# Altium schematic sheet SCM20260D-IO.SchDoc
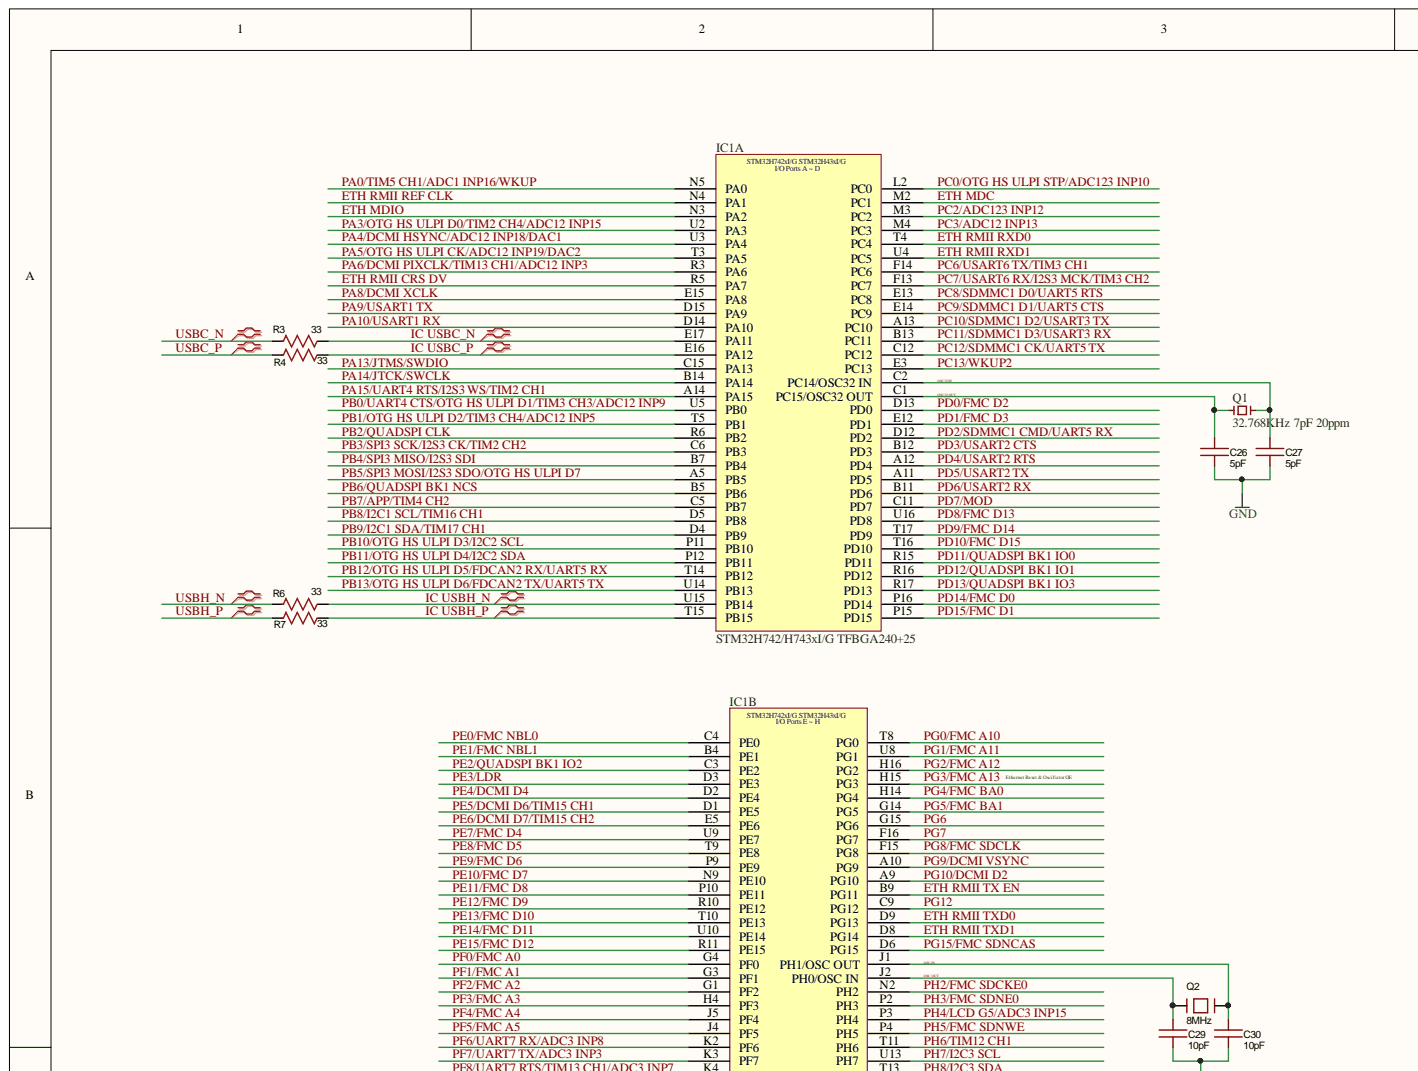
[diagram p0: SCM20260D-IO.SchDoc, top left]
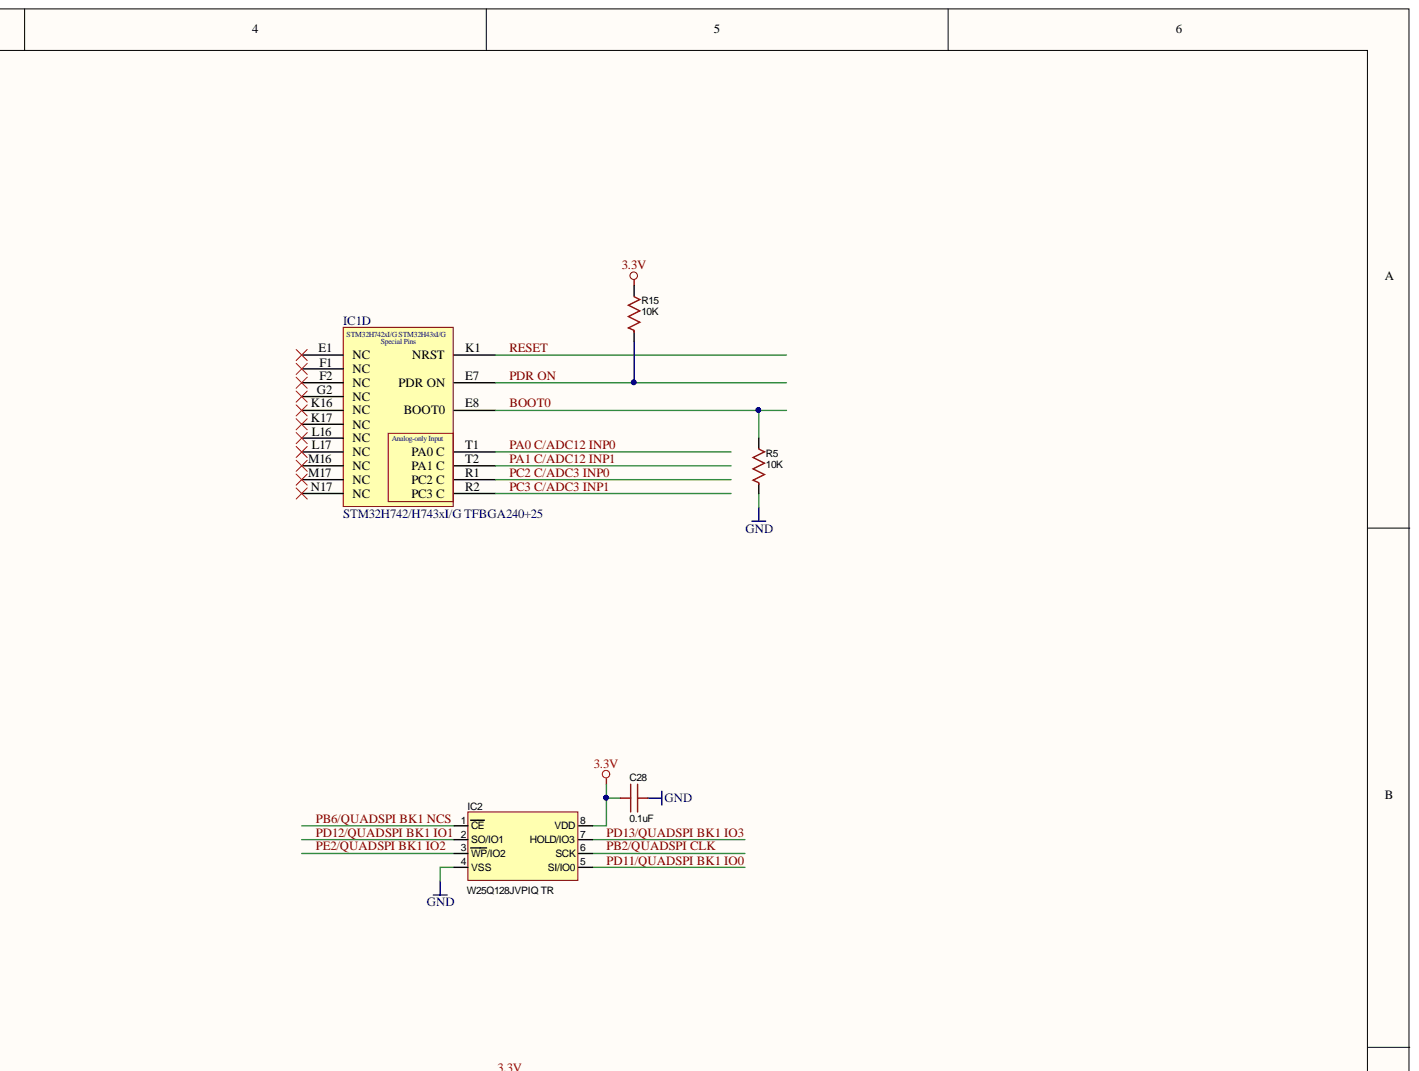
[diagram p1: SCM20260D-IO.SchDoc, top right]
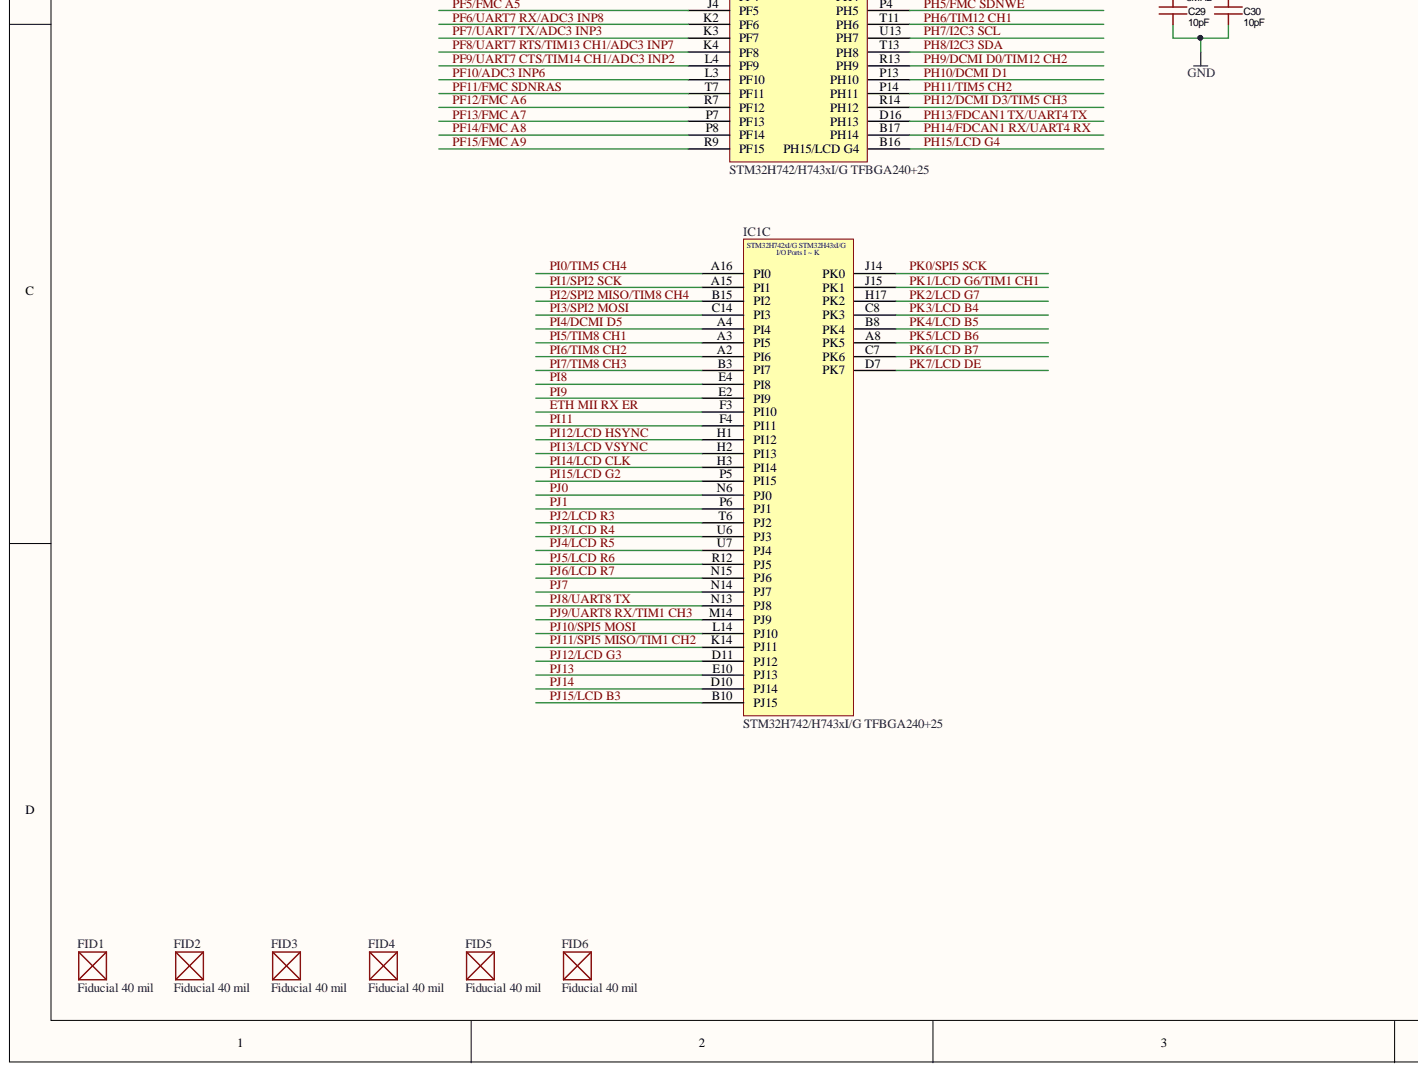
[diagram p2: SCM20260D-IO.SchDoc, bottom left]
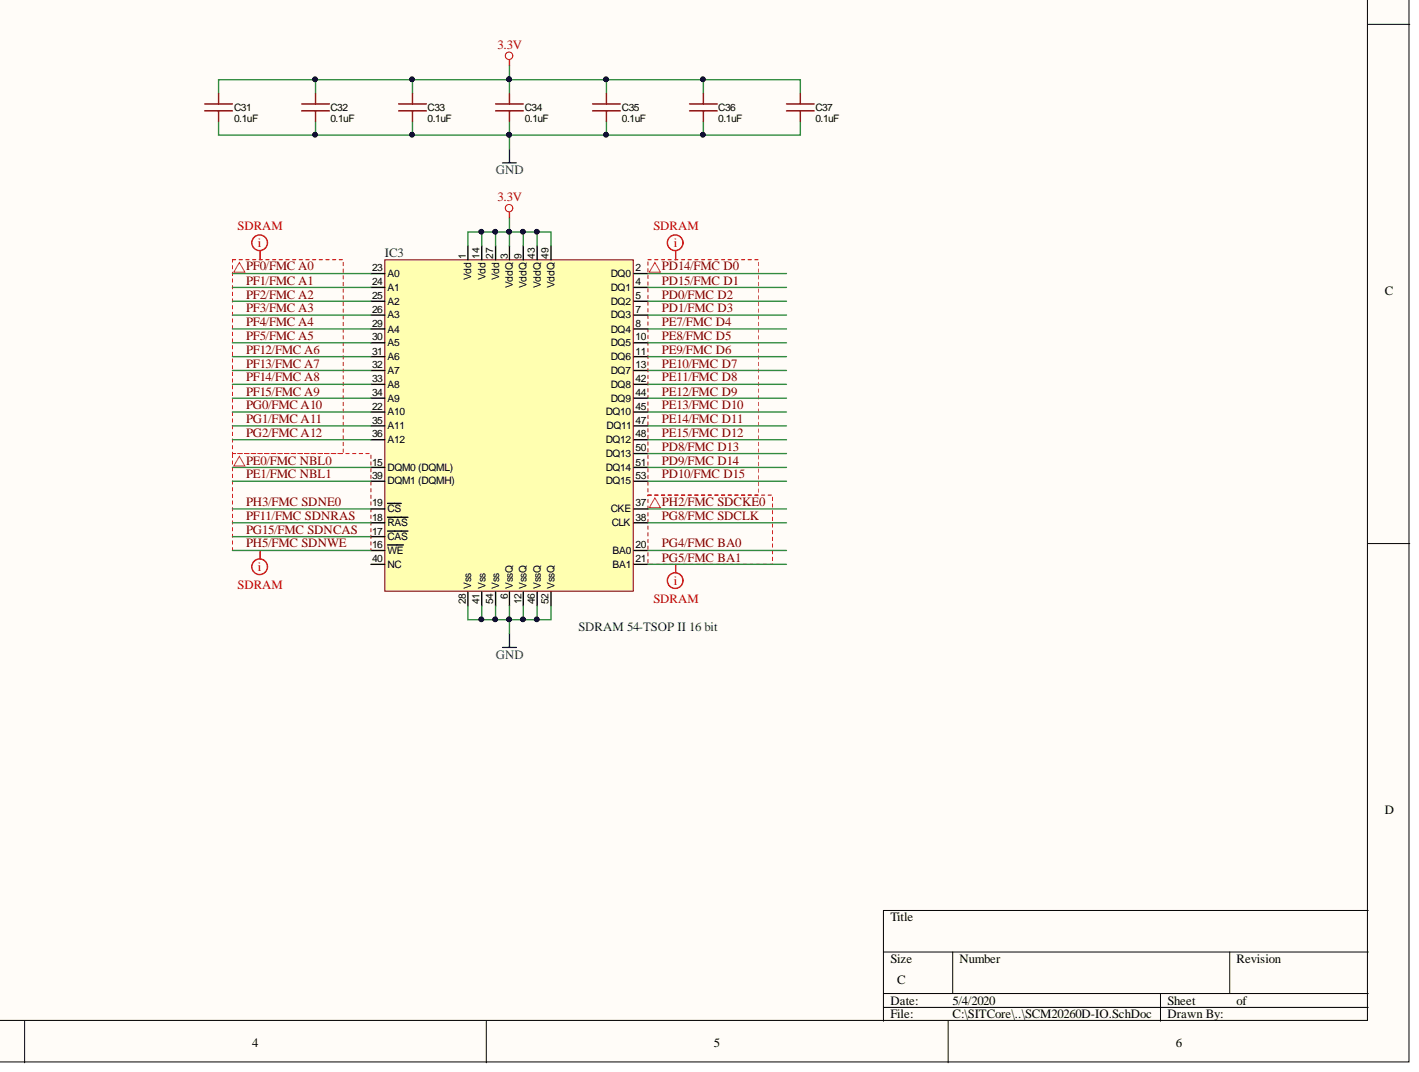
[diagram p3: SCM20260D-IO.SchDoc, bottom right]

## Parts
C26  value 5pF  Capacitance: 5pF  [C0402]  (hidden comment: 0402 Cap 5pF)
C27  value 5pF  Capacitance: 5pF  [C0402]  (hidden comment: 0402 Cap 5pF)
C28  value 0.1uF  Capacitance: 0.1uF  [C0402]  (hidden comment: 0402 Cap 0.1uF)
C29  value 10pF  Capacitance: 10pF  [C0402]  (hidden comment: 0402 Cap 10pF)
C30  value 10pF  Capacitance: 10pF  [C0402]  (hidden comment: 0402 Cap 10pF)
C31  value 0.1uF  Capacitance: 0.1uF  [C0402]  (hidden comment: 0402 Cap 0.1uF)
C32  value 0.1uF  Capacitance: 0.1uF  [C0402]  (hidden comment: 0402 Cap 0.1uF)
C33  value 0.1uF  Capacitance: 0.1uF  [C0402]  (hidden comment: 0402 Cap 0.1uF)
C34  value 0.1uF  Capacitance: 0.1uF  [C0402]  (hidden comment: 0402 Cap 0.1uF)
C35  value 0.1uF  Capacitance: 0.1uF  [C0402]  (hidden comment: 0402 Cap 0.1uF)
C36  value 0.1uF  Capacitance: 0.1uF  [C0402]  (hidden comment: 0402 Cap 0.1uF)
C37  value 0.1uF  Capacitance: 0.1uF  [C0402]  (hidden comment: 0402 Cap 0.1uF)
FID1  Fiducial 40 mil  [Fiducial 40 Mil]
FID2  Fiducial 40 mil  [Fiducial 40 Mil]
FID3  Fiducial 40 mil  [Fiducial 40 Mil]
FID4  Fiducial 40 mil  [Fiducial 40 Mil]
FID5  Fiducial 40 mil  [Fiducial 40 Mil]
FID6  Fiducial 40 mil  [Fiducial 40 Mil]
IC1  STM32H742/H743xI/G TFBGA240+25  [TFBGA240+25]  (parts A,B,C,D)
IC2  value W25Q128JVPIQ TR  Mfr.Part #: W25Q128JVPIQ TR  [WSON 8 6x5mm]  (hidden comment: FLASH - NOR Memory IC 128Mb)
IC3  SDRAM 54-TSOP II 16 bit  [TSOP-54]
Q1  32.768KHz 7pF 20ppm  Output Frequency: 32.768KHz  [Crystal 3.2mm x 1.5mm]
Q2  value 8MHz  [CRYSTAL_3.2X2.5]  (hidden comment: CRYSTAL3.2X2.5)
R3  value 33  [R0402]  (hidden comment: 0402 Res 33)
R4  value 33  [R0402]  (hidden comment: 0402 Res 33)
R5  value 10K  Resistance: 10K  [R0402]  (hidden comment: 0402 Res 10K)
R6  value 33  [R0402]  (hidden comment: 0402 Res 33)
R7  value 33  [R0402]  (hidden comment: 0402 Res 33)
R15  value 10K  Resistance: 10K  [R0402]  (hidden comment: 0402 Res 10K)

## Nets
3.3V: C28.1 C31.2 C32.2 C33.2 C34.2 C35.2 C36.2 C37.2 IC2.8(VDD) IC3.1(Vdd) IC3.3(VddQ) IC3.9(VddQ) IC3.14(Vdd) IC3.27(Vdd) IC3.43(VddQ) IC3.49(VddQ) R15.2
GND: C26.1 C27.1 C28.2 C29.1 C30.1 C31.1 C32.1 C33.1 C34.1 C35.1 C36.1 C37.1 IC2.4(VSS) IC3.6(VssQ) IC3.12(VssQ) IC3.28(Vss) IC3.41(Vss) IC3.46(VssQ) IC3.52(VssQ) IC3.54(Vss) R5.1
BOOT0: IC1.E8(BOOT0) R5.2
ETH MDC: IC1.M2(PC1)
ETH MDIO: IC1.N3(PA2)
ETH MII RX ER: IC1.F3(PI10)
ETH RMII CRS DV: IC1.R5(PA7)
ETH RMII REF CLK: IC1.N4(PA1)
ETH RMII RXD0: IC1.T4(PC4)
ETH RMII RXD1: IC1.U4(PC5)
ETH RMII TX EN: IC1.B9(PG11)
ETH RMII TXD0: IC1.D9(PG13)
ETH RMII TXD1: IC1.D8(PG14)
IC USBC_N: IC1.E17(PA11) R3.2
IC USBC_P: IC1.E16(PA12) R4.2
IC USBH_N: IC1.U15(PB14) R6.2
IC USBH_P: IC1.T15(PB15) R7.2
OSC32 IN: C27.2 IC1.C2(PC14/OSC32_IN) Q1.2
OSC32 OUT: C26.2 IC1.C1(PC15/OSC32_OUT) Q1.1
OSC IN: C30.2 IC1.J1(PH1/OSC_OUT) Q2.3
OSC OUT: C29.2 IC1.J2(PH0/OSC_IN) Q2.1
PA0 C/ADC12 INP0: IC1.T1(PA0_C)
PA0/TIM5 CH1/ADC1 INP16/WKUP: IC1.N5(PA0)
PA1 C/ADC12 INP1: IC1.T2(PA1_C)
PA3/OTG HS ULPI D0/TIM2 CH4/ADC12 INP15: IC1.U2(PA3)
PA4/DCMI HSYNC/ADC12 INP18/DAC1: IC1.U3(PA4)
PA5/OTG HS ULPI CK/ADC12 INP19/DAC2: IC1.T3(PA5)
PA6/DCMI PIXCLK/TIM13 CH1/ADC12 INP3: IC1.R3(PA6)
PA8/DCMI XCLK: IC1.E15(PA8)
PA9/USART1 TX: IC1.D15(PA9)
PA10/USART1 RX: IC1.D14(PA10)
PA13/JTMS/SWDIO: IC1.C15(PA13)
PA14/JTCK/SWCLK: IC1.B14(PA14)
PA15/UART4 RTS/I2S3 WS/TIM2 CH1: IC1.A14(PA15)
PB0/UART4 CTS/OTG HS ULPI D1/TIM3 CH3/ADC12 INP9: IC1.U5(PB0)
PB1/OTG HS ULPI D2/TIM3 CH4/ADC12 INP5: IC1.T5(PB1)
PB2/QUADSPI CLK: IC1.R6(PB2) IC2.6(SCK)
PB3/SPI3 SCK/I2S3 CK/TIM2 CH2: IC1.C6(PB3)
PB4/SPI3 MISO/I2S3 SDI: IC1.B7(PB4)
PB5/SPI3 MOSI/I2S3 SDO/OTG HS ULPI D7: IC1.A5(PB5)
PB6/QUADSPI BK1 NCS: IC1.B5(PB6) IC2.1(CE)
PB7/APP/TIM4 CH2: IC1.C5(PB7)
PB8/I2C1 SCL/TIM16 CH1: IC1.D5(PB8)
PB9/I2C1 SDA/TIM17 CH1: IC1.D4(PB9)
PB10/OTG HS ULPI D3/I2C2 SCL: IC1.P11(PB10)
PB11/OTG HS ULPI D4/I2C2 SDA: IC1.P12(PB11)
PB12/OTG HS ULPI D5/FDCAN2 RX/UART5 RX: IC1.T14(PB12)
PB13/OTG HS ULPI D6/FDCAN2 TX/UART5 TX: IC1.U14(PB13)
PC0/OTG HS ULPI STP/ADC123 INP10: IC1.L2(PC0)
PC2 C/ADC3 INP0: IC1.R1(PC2_C)
PC2/ADC123 INP12: IC1.M3(PC2)
PC3 C/ADC3 INP1: IC1.R2(PC3_C)
PC3/ADC12 INP13: IC1.M4(PC3)
PC6/USART6 TX/TIM3 CH1: IC1.F14(PC6)
PC7/USART6 RX/I2S3 MCK/TIM3 CH2: IC1.F13(PC7)
PC8/SDMMC1 D0/UART5 RTS: IC1.E13(PC8)
PC9/SDMMC1 D1/UART5 CTS: IC1.E14(PC9)
PC10/SDMMC1 D2/USART3 TX: IC1.A13(PC10)
PC11/SDMMC1 D3/USART3 RX: IC1.B13(PC11)
PC12/SDMMC1 CK/UART5 TX: IC1.C12(PC12)
PC13/WKUP2: IC1.E3(PC13)
PD0/FMC D2: IC1.D13(PD0) IC3.5(DQ2)
PD1/FMC D3: IC1.E12(PD1) IC3.7(DQ3)
PD2/SDMMC1 CMD/UART5 RX: IC1.D12(PD2)
PD3/USART2 CTS: IC1.B12(PD3)
PD4/USART2 RTS: IC1.A12(PD4)
PD5/USART2 TX: IC1.A11(PD5)
PD6/USART2 RX: IC1.B11(PD6)
PD7/MOD: IC1.C11(PD7)
PD8/FMC D13: IC1.U16(PD8) IC3.50(DQ13)
PD9/FMC D14: IC1.T17(PD9) IC3.51(DQ14)
PD10/FMC D15: IC1.T16(PD10) IC3.53(DQ15)
PD11/QUADSPI BK1 IO0: IC1.R15(PD11) IC2.5(SI/IO0)
PD12/QUADSPI BK1 IO1: IC1.R16(PD12) IC2.2(SO/IO1)
PD13/QUADSPI BK1 IO3: IC1.R17(PD13) IC2.7(HOLD/IO3)
PD14/FMC D0: IC1.P16(PD14) IC3.2(DQ0)
PD15/FMC D1: IC1.P15(PD15) IC3.4(DQ1)
PDR ON: IC1.E7(PDR_ON) R15.1
PE0/FMC NBL0: IC1.C4(PE0) IC3.15(DQM0_(DQML))
PE1/FMC NBL1: IC1.B4(PE1) IC3.39(DQM1_(DQMH))
PE2/QUADSPI BK1 IO2: IC1.C3(PE2) IC2.3(WP/IO2)
PE3/LDR: IC1.D3(PE3)
PE4/DCMI D4: IC1.D2(PE4)
PE5/DCMI D6/TIM15 CH1: IC1.D1(PE5)
PE6/DCMI D7/TIM15 CH2: IC1.E5(PE6)
PE7/FMC D4: IC1.U9(PE7) IC3.8(DQ4)
PE8/FMC D5: IC1.T9(PE8) IC3.10(DQ5)
PE9/FMC D6: IC1.P9(PE9) IC3.11(DQ6)
PE10/FMC D7: IC1.N9(PE10) IC3.13(DQ7)
PE11/FMC D8: IC1.P10(PE11) IC3.42(DQ8)
PE12/FMC D9: IC1.R10(PE12) IC3.44(DQ9)
PE13/FMC D10: IC1.T10(PE13) IC3.45(DQ10)
PE14/FMC D11: IC1.U10(PE14) IC3.47(DQ11)
PE15/FMC D12: IC1.R11(PE15) IC3.48(DQ12)
PF0/FMC A0: IC1.G4(PF0) IC3.23(A0)
PF1/FMC A1: IC1.G3(PF1) IC3.24(A1)
PF2/FMC A2: IC1.G1(PF2) IC3.25(A2)
PF3/FMC A3: IC1.H4(PF3) IC3.26(A3)
PF4/FMC A4: IC1.J5(PF4) IC3.29(A4)
PF5/FMC A5: IC1.J4(PF5) IC3.30(A5)
PF6/UART7 RX/ADC3 INP8: IC1.K2(PF6)
PF7/UART7 TX/ADC3 INP3: IC1.K3(PF7)
PF8/UART7 RTS/TIM13 CH1/ADC3 INP7: IC1.K4(PF8)
PF9/UART7 CTS/TIM14 CH1/ADC3 INP2: IC1.L4(PF9)
PF10/ADC3 INP6: IC1.L3(PF10)
PF11/FMC SDNRAS: IC1.T7(PF11) IC3.18(RAS)
PF12/FMC A6: IC1.R7(PF12) IC3.31(A6)
PF13/FMC A7: IC1.P7(PF13) IC3.32(A7)
PF14/FMC A8: IC1.P8(PF14) IC3.33(A8)
PF15/FMC A9: IC1.R9(PF15) IC3.34(A9)
PG0/FMC A10: IC1.T8(PG0) IC3.22(A10)
PG1/FMC A11: IC1.U8(PG1) IC3.35(A11)
PG2/FMC A12: IC1.H16(PG2) IC3.36(A12)
PG3/FMC A13: IC1.H15(PG3)
PG4/FMC BA0: IC1.H14(PG4) IC3.20(BA0)
PG5/FMC BA1: IC1.G14(PG5) IC3.21(BA1)
PG6: IC1.G15(PG6)
PG7: IC1.F16(PG7)
PG8/FMC SDCLK: IC1.F15(PG8) IC3.38(CLK)
PG9/DCMI VSYNC: IC1.A10(PG9)
PG10/DCMI D2: IC1.A9(PG10)
PG12: IC1.C9(PG12)
PG15/FMC SDNCAS: IC1.D6(PG15) IC3.17(CAS)
PH2/FMC SDCKE0: IC1.N2(PH2) IC3.37(CKE)
PH3/FMC SDNE0: IC1.P2(PH3) IC3.19(CS)
PH4/LCD G5/ADC3 INP15: IC1.P3(PH4)
PH5/FMC SDNWE: IC1.P4(PH5) IC3.16(WE)
PH6/TIM12 CH1: IC1.T11(PH6)
PH7/I2C3 SCL: IC1.U13(PH7)
PH8/I2C3 SDA: IC1.T13(PH8)
PH9/DCMI D0/TIM12 CH2: IC1.R13(PH9)
PH10/DCMI D1: IC1.P13(PH10)
PH11/TIM5 CH2: IC1.P14(PH11)
PH12/DCMI D3/TIM5 CH3: IC1.R14(PH12)
PH13/FDCAN1 TX/UART4 TX: IC1.D16(PH13)
PH14/FDCAN1 RX/UART4 RX: IC1.B17(PH14)
PH15/LCD G4: IC1.B16(PH15/LCD_G4)
PI0/TIM5 CH4: IC1.A16(PI0)
PI1/SPI2 SCK: IC1.A15(PI1)
PI2/SPI2 MISO/TIM8 CH4: IC1.B15(PI2)
PI3/SPI2 MOSI: IC1.C14(PI3)
PI4/DCMI D5: IC1.A4(PI4)
PI5/TIM8 CH1: IC1.A3(PI5)
PI6/TIM8 CH2: IC1.A2(PI6)
PI7/TIM8 CH3: IC1.B3(PI7)
PI8: IC1.E4(PI8)
PI9: IC1.E2(PI9)
PI11: IC1.F4(PI11)
PI12/LCD HSYNC: IC1.H1(PI12)
PI13/LCD VSYNC: IC1.H2(PI13)
PI14/LCD CLK: IC1.H3(PI14)
PI15/LCD G2: IC1.P5(PI15)
PJ0: IC1.N6(PJ0)
PJ1: IC1.P6(PJ1)
PJ2/LCD R3: IC1.T6(PJ2)
PJ3/LCD R4: IC1.U6(PJ3)
PJ4/LCD R5: IC1.U7(PJ4)
PJ5/LCD R6: IC1.R12(PJ5)
PJ6/LCD R7: IC1.N15(PJ6)
PJ7: IC1.N14(PJ7)
PJ8/UART8 TX: IC1.N13(PJ8)
PJ9/UART8 RX/TIM1 CH3: IC1.M14(PJ9)
PJ10/SPI5 MOSI: IC1.L14(PJ10)
PJ11/SPI5 MISO/TIM1 CH2: IC1.K14(PJ11)
PJ12/LCD G3: IC1.D11(PJ12)
PJ13: IC1.E10(PJ13)
PJ14: IC1.D10(PJ14)
PJ15/LCD B3: IC1.B10(PJ15)
PK0/SPI5 SCK: IC1.J14(PK0)
PK1/LCD G6/TIM1 CH1: IC1.J15(PK1)
PK2/LCD G7: IC1.H17(PK2)
PK3/LCD B4: IC1.C8(PK3)
PK4/LCD B5: IC1.B8(PK4)
PK5/LCD B6: IC1.A8(PK5)
PK6/LCD B7: IC1.C7(PK6)
PK7/LCD DE: IC1.D7(PK7)
RESET: IC1.K1(NRST)
USBC_N: R3.1
USBC_P: R4.1
USBH_N: R6.1
USBH_P: R7.1
N00230:
N00231:

Not connected: IC1 E1(NC) F1(NC) F2(NC) G2(NC) K16(NC) K17(NC) L16(NC) L17(NC) M16(NC) M17(NC) N17(NC); IC3 40(NC)

## Library data of the parts
C26 C27 = 0402 Cap 5pF: 5pF ±0.1pF 50V C0G 0402 Multilayer Ceramic Capacitors MLCC - SMD/SMT RoHS; Manufacturer: Samsung Electro-Mechanics; Mfr.Part #: CL05C050BB5NNNC; Category: Ceramic; Component Kind: Standard; LCSC Part #: C318616; Package: 0402; Tolerance: ±0.1pF; Voltage: 50V; Library Name: GHI-Passives.SchLib
C28 C31-C37 = 0402 Cap 0.1uF: 0.1uF ±10% 16V X7R 0402 Multilayer Ceramic Capacitors MLCC - SMD/SMT RoHS; Mfr.Part #: CC0402KRX7R7BB104; Category: Ceramic; Component Kind: Standard; LCSC Part #: C60474; Package: 0402; Tolerance: ±10%; Voltage: 16V; Library Name: GHI-Passives.SchLib
C29 C30 = 0402 Cap 10pF: 10pF ±0.5pF 50V C0G 0402 Multilayer Ceramic Capacitors MLCC - SMD/SMT RoHS; Manufacturer: Samsung Electro-Mechanics; Mfr.Part #: CL05C100DB5NNNC; Category: Ceramic; Component Kind: Standard; LCSC Part #: C307440; Package: 0402; Tolerance: ±0.5pF; Voltage: 50V; Library Name: GHI-Passives.SchLib
IC1 = STM32H742/H743xI/G TFBGA240+25: STM32H742/H743xI/G TFBGA240+25 (14 x 14mm); Component Kind: Standard; Library Name: GHI Processors.SchLib
IC2 = W25Q128JVPIQ: Manufacturer: Winbond Elec; Component Kind: Standard; Description: FLASH - NOR Memory IC 128Mb; Digikey Part No: W25Q128JVPIQCT-ND; LCSC Part #: C190862; Package: 8-WDFN Exposed Pad; Library Name: GHI - Integrated Circuits.SchLib
Q1 = 32.768KHz 7pF 20ppm 2 Pad 3.2mm x 1.5mm: Crystal 2 Pad 3.2mm x 1.5mm 32.768KHz; GHI Part Number: EPC-Q32KHZ; Manufacturer Part Number: 6K30032768K0702070401; Aging @25 C 1st Year (Max): +- 3ppm/year; Driver Level (Typical): 1uW Max; Equivalent Series Resistance: 70k Ohm Max; Frequency Tolerance: +- 20ppm; Load Capacitance: 10 pF; Mode: AT/Fundamental; Shunt Capacitance: 1.3pF Typ; Component Kind: Standard; Frequency Stability: N/A; Library Name: GHI Oscillators.SchLib; Operating Temperature Range: -40 C to +85 C; Shock Resistance: N/A; Storage Temperature: -55C to +125 C
Q2 = CRYSTAL3.2X2.5: 4 pad 3.2 mm x 2.5 mm Crystal; GateName_1: G$1; SymbolName_1: CRYSTAL.2PAD; Component Kind: Standard; DeviceSetName: CRYSTAL3.2X2.5; LibraryName: GHI2; Library Name: GHI.SchLib
R3 R4 R6 R7 = 0402 Res 33: 33 ±1% 1/16W ±100ppm/℃ 0402 Chip Resistor - Surface Mount RoHS; Manufacturer: YAGEO; Mfr.Part #: RC0402FR-0733RL; Voltage Rating: *V; Component Kind: Standard; LCSC Part #: C138002; Package: 0402; Power Rating: 1/16W; Resistance: 33; Resistance Tolerance: ±1%; Library Name: GHI-Passives.SchLib
R5 R15 = 0402 Res 10K: 10K ±1% 1/16W ±100ppm/℃ 0402 Chip Resistor - Surface Mount RoHS; Manufacturer: YAGEO; Mfr.Part #: RC0402FR-0710KL; Voltage Rating: *V; Component Kind: Standard; LCSC Part #: C60490; Package: 0402; Power Rating: 1/16W; Resistance Tolerance: ±1%; Library Name: GHI-Passives.SchLib
FID1-FID6 = Fiducial 40 Mil: Component Kind: Standard; Library Name: GHI Miscellaneous Components.SchLib
IC3 = SDRAM 54-TSOP II 16 bit: Component Kind: Standard; Description: SDRAM 54-TSOP II 16 bit; Library Name: GHI.SchLib
